# Altium schematic sheet Sheet1(28Pin).SchDoc
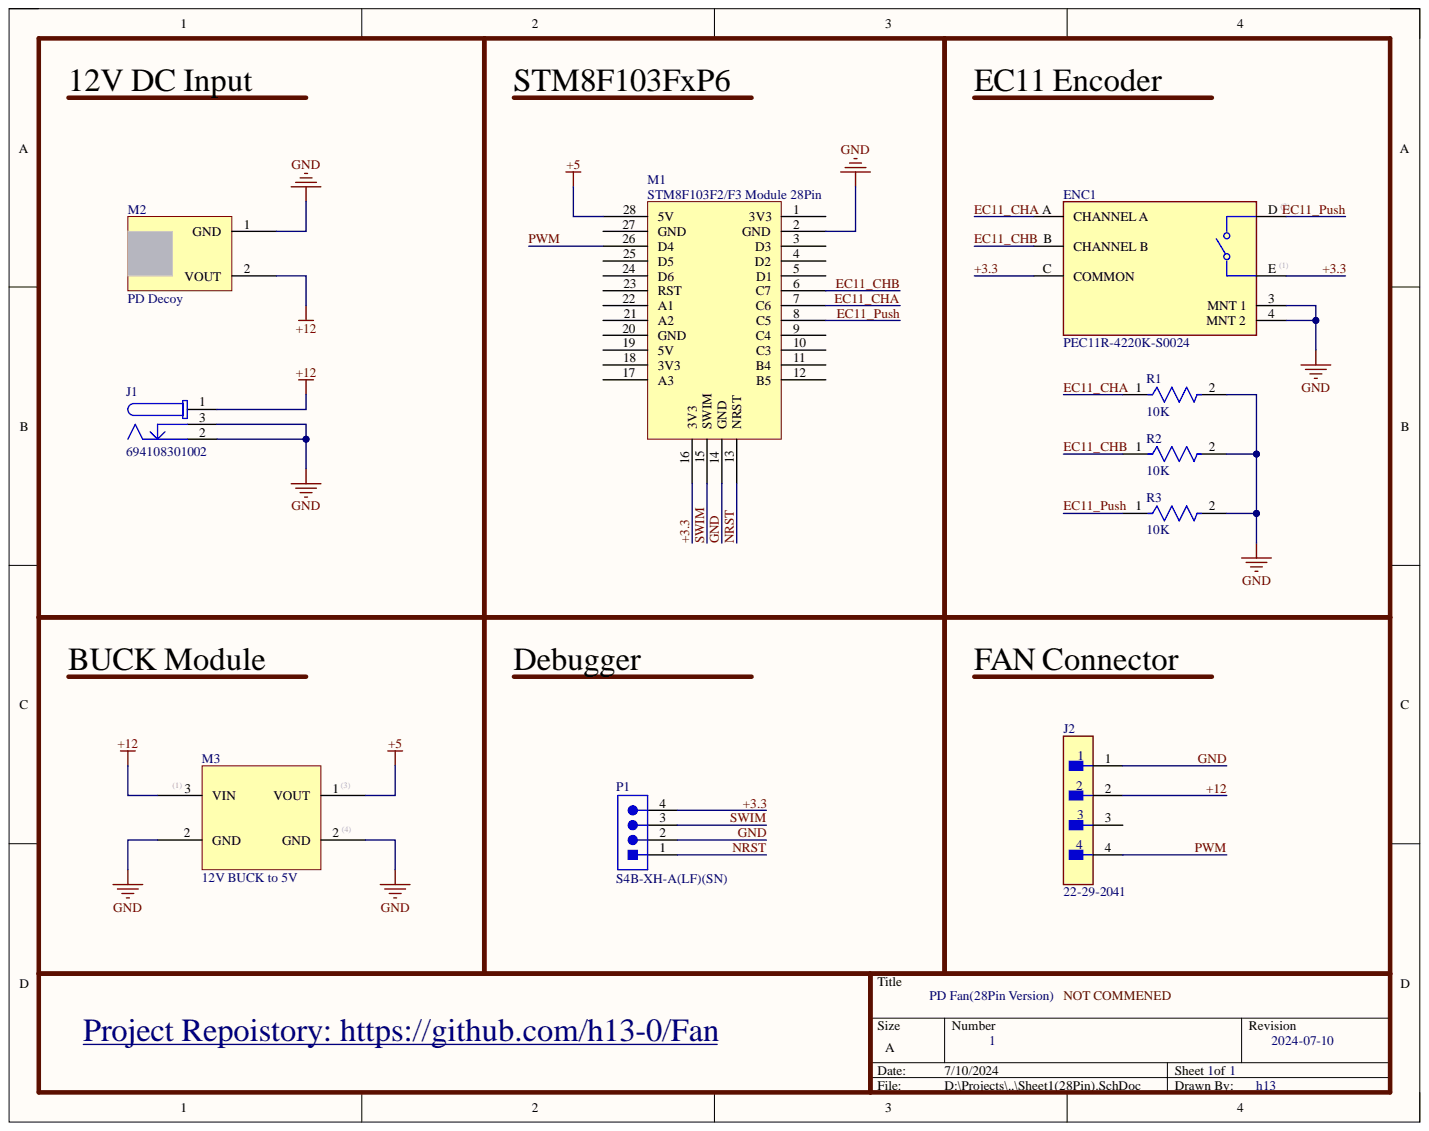
[diagram p0: Sheet1(28Pin).SchDoc]

## Parts
ENC1  PEC11R-4220K-S0024  [EC11]
J1  694108301002  [694108301002]
J2  22-29-2041  [FP-22-29-2041-MFG]
M1  STM8F103F2/F3 Module 28Pin  [STM8FxP6 Module 28Pin]
M2  PD Decoy  [PD Decoy]
M3  12V BUCK to 5V  [Buck Mini]
P1  S4B-XH-A(LF)(SN)  [JST-S4B-XH-A(LF)(SN)_V]
R1  10K  [FP-MRS25-MFG]
R2  10K  [FP-MRS25-MFG]
R3  10K  [FP-MRS25-MFG]

## Nets
+5: M1.28(5V) M3.3(VOUT)
+3.3: ENC1.1 ENC1.C(COMMON) M1.16(3V3) P1.4
+12: J1.1 J2.2 M2.2 M3.1(VIN)
EC11_CHA: ENC1.A(CHANNEL_A) M1.7(C6) R1.1
EC11_CHB: ENC1.B(CHANNEL_B) M1.6(C7) R2.1
EC11_Push: ENC1.2 M1.8(C5) R3.1
GND: ENC1.3(MNT_1) ENC1.4(MNT_2) J1.2 J1.3 J2.1 M1.2(GND) M1.14(GND) M2.1 M3.2(GND) M3.4(GND) P1.2 R1.2 R2.2 R3.2
NRST: M1.13(NRST) P1.1
PWM: J2.4 M1.26(D4)
SWIM: M1.15(SWIM) P1.3

Not connected: J2 3; M1 1(3V3) 3(D3) 4(D2) 5(D1) 9(C4) 10(C3) 11(B4) 12(B5) 17(A3) 18(3V3) 19(5V) 20(GND) 21(A2) 22(A1) 23(RST) 24(D6) 25(D5) 27(GND)

## Library data of the parts
ENC1 = CMP-07421-000070-1: ROTARY ENCODER INCREMENTAL 24PPR; Actuator Type: Shaft; Built in Switch: Yes; Hole Diameter: 1mm; Nominal Supply Voltage (DC): 5V; Number of Channels: 2; Output Type: Quadrature; Pulses per Revolution: 24; Component Kind: Standard; Detent: Yes; Encoder Type: Incremental; Height: 13.4mm; Length: 26.5mm; Library Name: Altium Content Vault; Max Operating Temperature: 70°C; Min Operating Temperature: -30°C; Mount: Through Hole; Number of Pins: 5; Orientation: Vertical; Package Quantity: 75; Packaging: Bulk; REACH SVHC: No SVHC; RoHS Compliant: Yes; Series: PEC11R; Termination: PC Pin; Width: 12.5mm
J1 = CMP-1502-00190-3: NexarPartId: 52676102; Connector Type: Power; Current Rating: 5A; Gender: Male; Insulation Resistance: 100MΩ; Number of Contacts: 3; Operating Supply Voltage: 24V; Shielding: Unshielded; Voltage Rating (DC): 20V; Color: Black; Component Kind: Standard; Contact Gender: Female; Contact Material: Gold, Tin; Contact Plating: Tin; Flammability Rating: UL94 V-0; Height: 11mm; Housing Material: Polyamide; Library Name: Altium Content Vault; Max Operating Temperature: 85°C; Min Operating Temperature: -40°C; Mount: Through Hole; Packaging: Bulk; REACH SVHC: Unknown; RoHS: Compliant; Termination: Through Hole; Voltage Rating: 24V
J2 = CMP-04776-000366-1: CONN HEADER VERT 4POS 2.54MM; Contact Resistance: 10mR; Current Rating: 4A; Gender: Male; Glow Wire Compliant: Non-compliant; Insulation Resistance: 50GR; Number of Circuits: 4; Number of Lines: 1; Voltage Rating: 250V; Color: Natural; Component Kind: Standard; Contact Gender: Male; Contact Material: Brass; Contact Mating Length: 0.295inch; Contact Plating: Gold; Contact Type: Male Pin; Depth: 5.8mm; Fastening Type: Friction; Flammability Rating: UL94 V-0; Halogen Free: Low Halogen; Height: 11.7mm; Housing Color: White; Housing Material: Nylon; Lead Free: Lead Free; Length: 10.16mm; Library Name: Altium Content Vault; Max Current Rating: 4A; Max Operating Temperature: 75°C; Min Operating Temperature: 0°C; Mount: Through Hole; Number of Contacts: 4; Number of Poles: 4; Number of Positions: 4; Number of Rows: 1; Orientation: Straight; Package Quantity: 1500; Packaging: Bulk; Pitch: 2.54mm; Radiation Hardening: No; RoHS Compliant: Yes; Series: KK® 6410; Termination: Solder; Voltage Rating (DC): 250V
M3 = BUCK | BOOST: Component Kind: Standard; Library Name: BUCK_BOOST.SchLib
P1 = CMP-1755-00007-1: Male Header, Pitch 2.5 mm, 1 x 4 Position, Height 6.1 mm, Tail Length 3.4 mm, -25 to 85 degC, RoHS, Bulk; Component Kind: Standard; ComponentLink1Description: Manufacturer URL; ComponentLink1URL: http://www.jst.com/home2.html; ComponentLink2Description: Datasheet; ComponentLink2URL: http://www.jst-mfg.com/product/pdf/eng/eXH.pdf; DatasheetVersion: May-16; Library Name: Altium Content Vault; PackageDescription: Male Header, Pitch 2.5 mm, 1 x 4 Position, Height 6.1 mm, Tail Length 3.4 mm; PackageReference: S4B-XH-A(LF)(SN)
R1-R3 = CMP-02408-000051-1: RES 1K OHM 0.6W 1% AXIAL; Lead Diameter: 0.6mm; Max Power Dissipation: 600mW; Resistance: 1kR; Temperature Coefficient: 50ppm/°C; Voltage Rating: 350V; Case/Package: Axial; Component Kind: Standard; Construction: Cylindrical; Contact Plating: Tin; Diameter: 2.5mm; Lead Free: Lead Free; Length: 6.5mm; Library Name: Altium Content Vault; Max Operating Temperature: 155°C; Military Standard: Not; Min Operating Temperature: -55°C; Mount: Through Hole; Number of Pins: 2; Number of Terminations: 2; Package Quantity: 5000; Packaging: Tape and Reel; Power Rating: 600mW; Radiation Hardening: No; Resistance Tolerance: 1%; Resistor Type: Metal Film; RoHS Compliant: Yes; Series: MRS25; Technology: THIN FILM; Termination: Axial; Tolerance: 1%; Voltage Rating (DC): 350V; Weight: 0.00776oz; Width: 2.5mm
M1 = STM8F103F2/F3 Module 28Pin: Component Kind: Standard; Library Name: STM8S103F.SchLib
M2 = PD Decoy: Component Kind: Standard; Library Name: PD_Decoy.SchLib
